# Altium schematic sheet InputChoke.SchDoc(InputChoke)
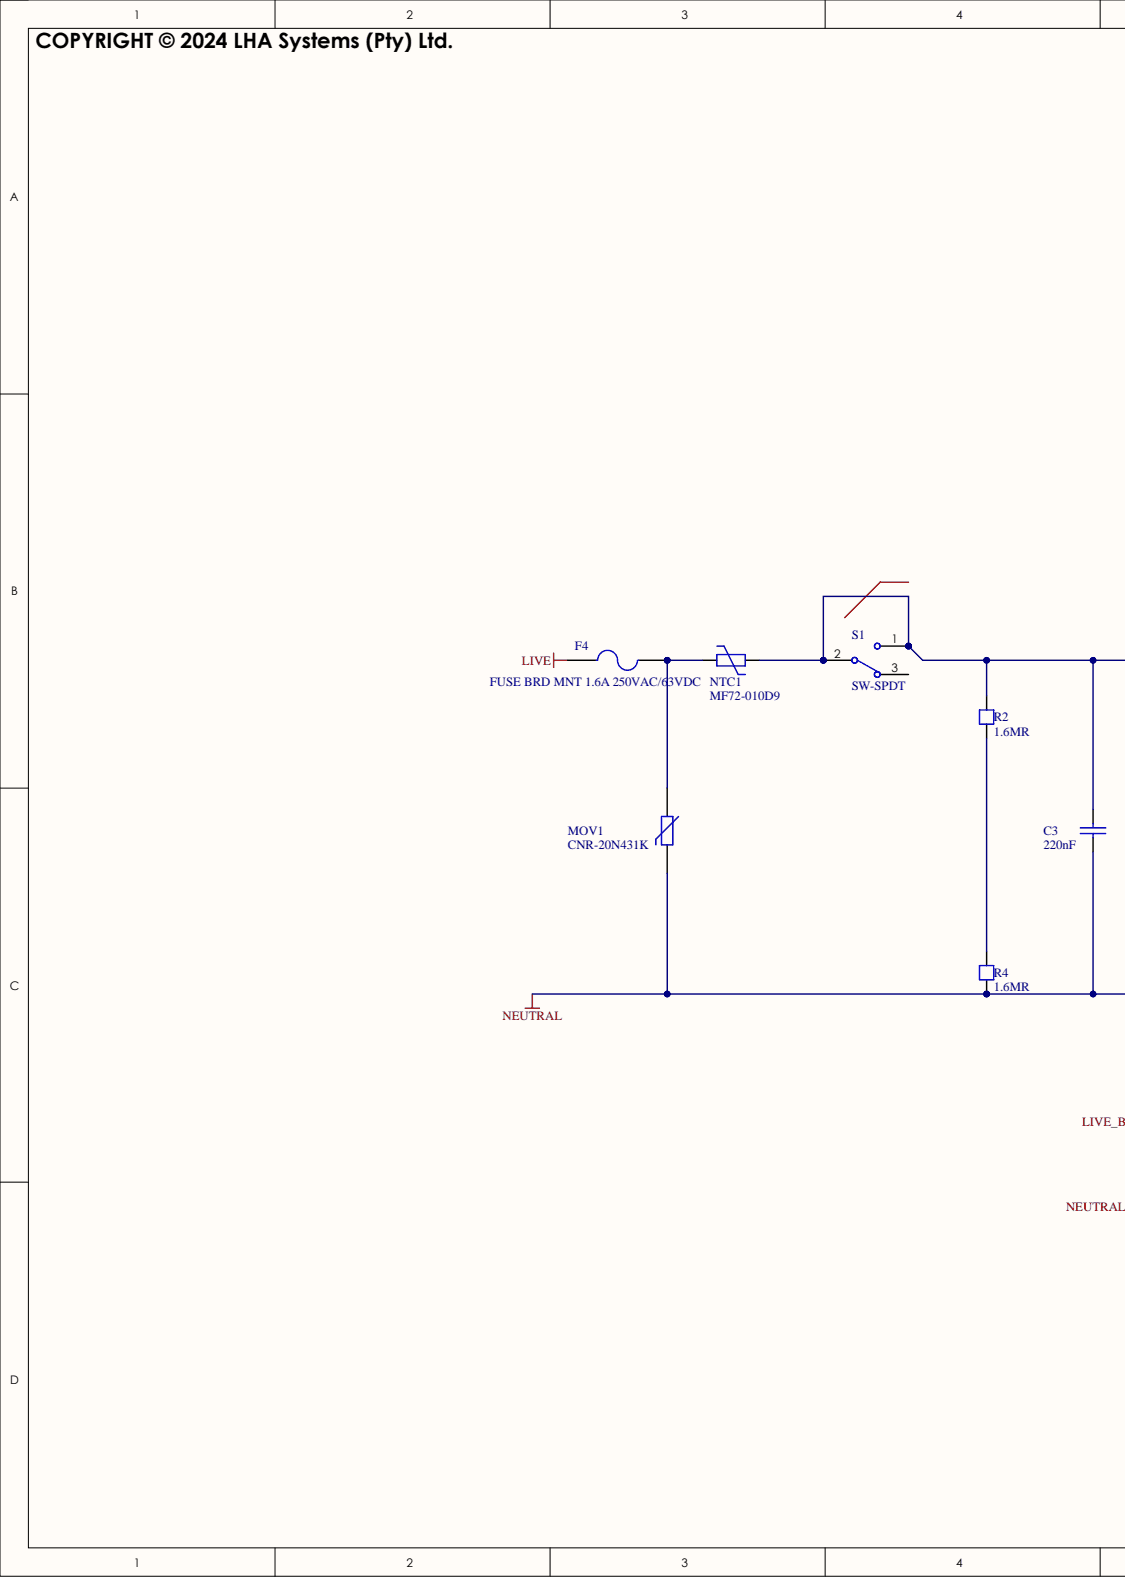
[diagram p0: InputChoke.SchDoc, left]
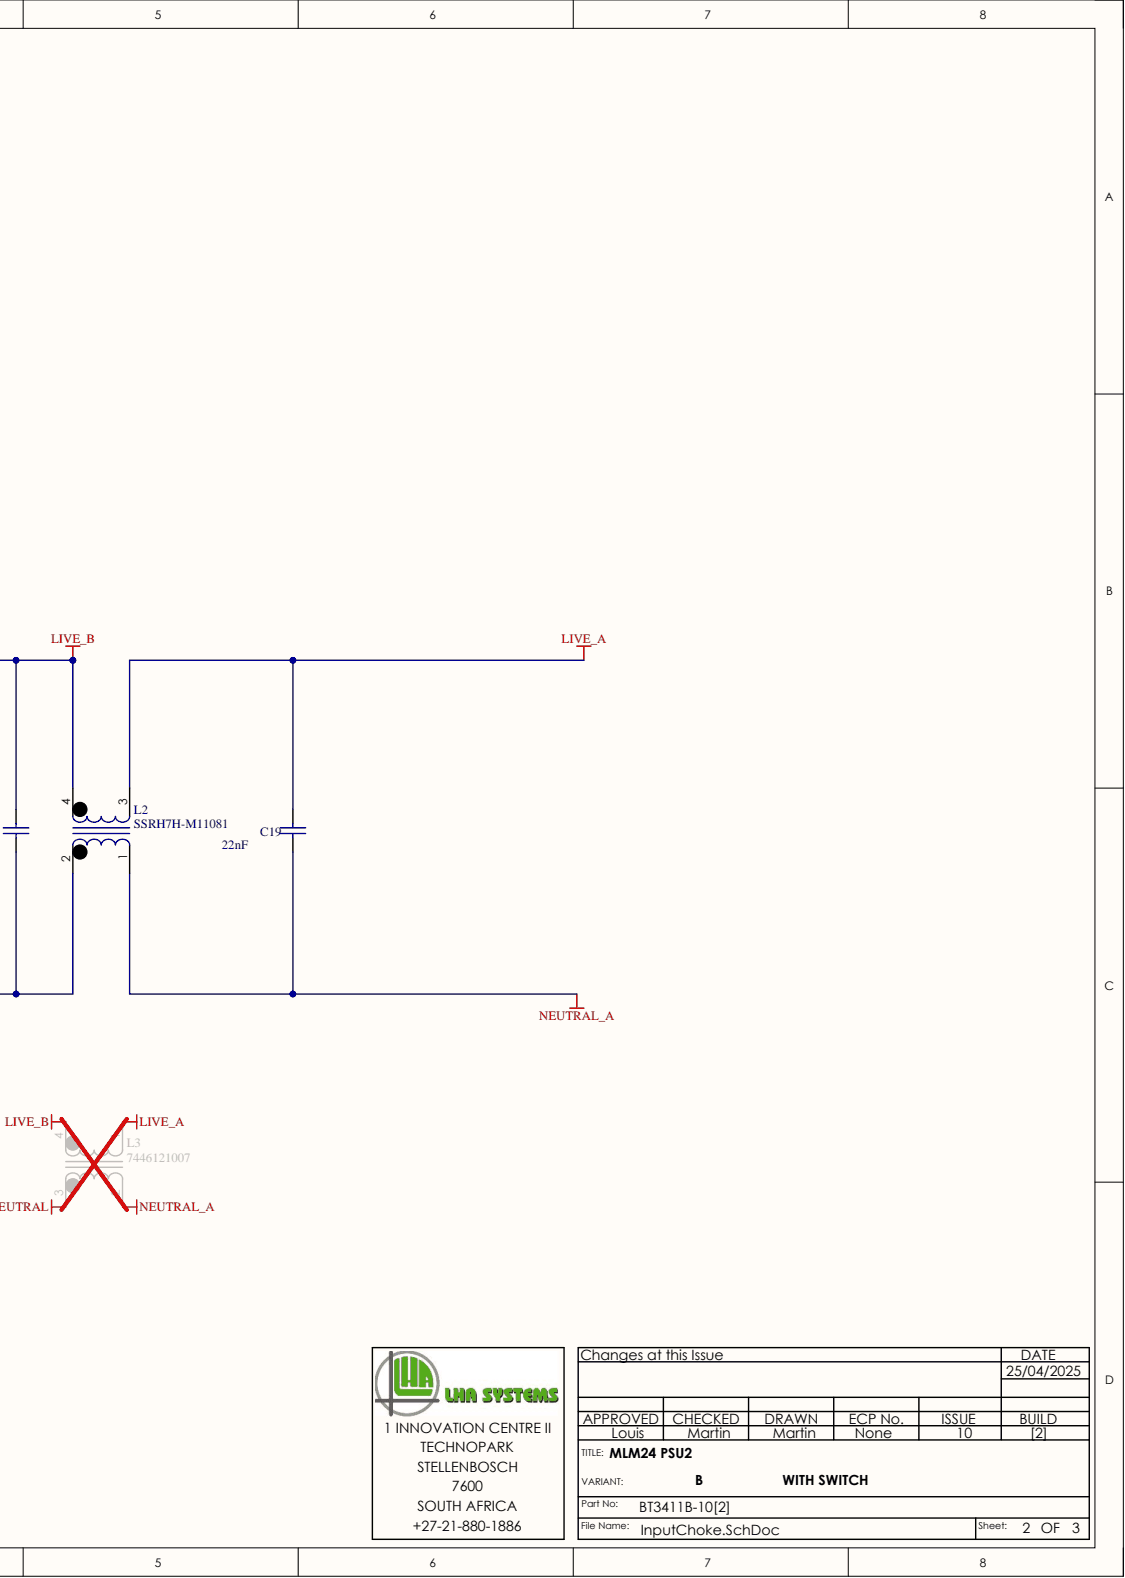
[diagram p1: InputChoke.SchDoc, right]

## Parts
C3  220nF  [Y2/X2 CAP 15MM]
C19  22nF  [RADIAL H11.5xL15xW5xPitch12.5]
F4  FUSE BRD MNT 1.6A 250VAC/63VDC  Current Rating: 1.6A  [RSTA 1.6 AMMO]
L2  SSRH7H-M11081  [SSRH7H-M]
L3  7446121007  [7446121007]
MOV1  CNR-20N431K  [CNR-20D431K]
NTC1  MF72-010D9  [LEADED DISK 7.5mm pitch]
R2  1.6MR  [1206 - OCTO]
R4  1.6MR  [1206 - OCTO]
S1  SW-SPDT  [JS-606B-R-B/B-1]

## Nets
LIVE: F4.1
LIVE_A: C19.1 L2.3 L3.1
LIVE_B: C3.1 L2.4 L3.4 NTC1.2 R2.2 S1.1 S1.2
NEUTRAL: C3.2 L2.2 L3.3 MOV1.2 R4.1
NEUTRAL_A: C19.2 L2.1 L3.2
NetF4_2: F4.2 MOV1.1 NTC1.1
NetR2_1: R2.1 R4.2

Not connected: S1 3

## Library data of the parts
C3 = CAP: Cap Polyester; Manufacturer: Panasonic Electronic Components; Manufacturer Part Number: ECQ-UAAF224M; Part Description: CAP  Polypropylene, Metallized X2 220nF 275V Radial ±20%  15.00mm; Dielectric Material: Polypropylene, Metallized; LHADescription: CAP FILM 0.22UF 20% 275VAC RAD X2; Tolerance: ±20%; Voltage Rated: 275V; Applications: X2; Capacitance: 220nF; Category: Capacitors; Component Kind: Standard; ComponentLink1Description: http://industrial.panasonic.com/www-cgi/jvcr13pz.cgi?E+PZ+4+ABD0050+ECQUAAF224M+8+WW; ComponentLink1URL: http://industrial.panasonic.com/www-cgi/jvcr13pz.cgi?E+PZ+4+ABD0050+ECQUAAF224M+8+WW; ESR (Equivalent Series Resistance): -; Features: EMI Suppression; Height - Seated (Max): 0.571" (14.50mm); Lead Pitch: 15.00mm; Mounting Type: Through Hole; Operating Temperature: -40°C ~ 110°C; PackageCase: Radial; Packaging: Bulk; Part Status: Active; Pricing: 1=0.58, 10=0.413, 25=0.3524, 50=0.3122, 100=0.2719, 250=0.2316, 500=0.2014, 1000=0.17119, 2500=0.16112 (USD); Pricing 1: 1=0.54, 10=0.38, 100=0.25, 500=0.1852, 1000=0.15742, 2500=0.14816, 5000=0.1389 (USD); RoHS: RoHS Compliant; Size / Dimension: 0.689" L x 0.295" W (17.50mm x 7.50mm); Stock: 25690; Stock 1: 240535; Supplier 1: Digi-Key; Supplier Part Number 1: P14781-ND; Termination: PC Pins; Type: CAP; Voltage Rating - AC: 275V; Voltage Rating - DC: -
C19 = CAP: Cap Polyester; Manufacturer: Panasonic Electronic Components; Manufacturer Part Number: ECQ-U2A223ML; Part Description: CAP  Polyester, Metallized X2 22nF 275V Radial ±20%  12.50mm; Dielectric Material: Polyester, Metallized; LHADescription: CAP FILM 0.022UF 20% 275VAC RAD X2; Tolerance: ±20%; Voltage Rated: 275V; Applications: X2; Capacitance: 22nF; Category: Capacitors; Component Kind: Standard; ComponentLink1Description: http://industrial.panasonic.com/www-cgi/jvcr13pz.cgi?E+PZ+3+ABD0029+ECQU2A223ML+7+WW; ComponentLink1URL: http://industrial.panasonic.com/www-cgi/jvcr13pz.cgi?E+PZ+3+ABD0029+ECQU2A223ML+7+WW; ESR (Equivalent Series Resistance): -; Features: EMI Suppression; Height - Seated (Max): 0.472" (12.00mm); Lead Pitch: 12.50mm; Mounting Type: Through Hole; Operating Temperature: -40°C ~ 100°C; PackageCase: Radial; Packaging: Bulk; Part Status: Active; Pricing: 1=0.46, 10=0.327, 25=0.2792, 50=0.2474, 100=0.2155, 250=0.18356, 500=0.1596, 1000=0.13566, 2500=0.12768 (USD); Pricing 1: 1=0.47, 10=0.323, 100=0.1938, 500=0.14536, 1000=0.12274, 2500=0.11628, 5000=0.10982 (USD); RoHS: RoHS Compliant; Size / Dimension: 0.591" L x 0.197" W (15.00mm x 5.00mm); Stock: 6350; Stock 1: 3323; Supplier 1: Digi-Key; Supplier Part Number 1: P10726-ND; Termination: PC Pins; Type: CAP; Voltage Rating - AC: 275V; Voltage Rating - DC: -
F4 = Fuse 2: Fuse; Manufacturer: Bel TRP; Manufacturer Part Number: RSTA1.6AMMO; Approvals: VDE,cURus,CQC,PSE; DC Cold Resistance: 44mR; Fuse Type: Board Mount (Cartridge Style Excluded); Voltage Rating: 72V; Voltage Rating (AC): 250V; Voltage Rating (DC): 63V; Case/Package: Radial; Category: Circuit Protection; Component Kind: Standard; ComponentLink1Description: http://belfuse.com/pdfs/RSTA.pdf?src-supplier=Avnet+Asia; ComponentLink1URL: http://belfuse.com/pdfs/RSTA.pdf?src-supplier=Avnet+Asia; ComponentLink2Description: https://belfuse.com/resources/StewartConnector/RSTA.pdf?src-supplier=Digi-Key; ComponentLink2URL: https://belfuse.com/resources/StewartConnector/RSTA.pdf?src-supplier=Digi-Key; ComponentLink3Description: http://belfuse.com/pdfs/RSTA.pdf?src-supplier=Avnet; ComponentLink3URL: http://belfuse.com/pdfs/RSTA.pdf?src-supplier=Avnet; ComponentLink4Description: http://www.mouser.com/ds/2/643/0697W-594970.pdf?src-supplier=Mouser; ComponentLink4URL: http://www.mouser.com/ds/2/643/0697W-594970.pdf?src-supplier=Mouser; ComponentLink5Description: http://belfuse.com/pdfs/0697W.pdf?src-supplier=Avnet; ComponentLink5URL: http://belfuse.com/pdfs/0697W.pdf?src-supplier=Avnet; ComponentLink6Description: http://www.belfuse.com/resources/CircuitProtection/datasheets/0697W Mar2016.pdf?src-supplier=Digi-Key; ComponentLink6URL: http://www.belfuse.com/resources/CircuitProtection/datasheets/0697W Mar2016.pdf?src-supplier=Digi-Key; Contact Plating: Tin,Matte; Height: 0.307inch; Length: 0.329inch; LHADescription: FUSE BRD MNT 1.6A 250VAC/63VDC; Max Operating Temperature: 125°C; Min Operating Temperature: -55°C; Mount: Through Hole; Number of Pins: 2; PackageCase: Radial; Packaging: Cut Tape; Part Description: FUSE BRD MNT 1.6A 250VAC/63VDC; Pricing 1: 1000=0.15761, 2000=0.14102, 3000=0.13272, 5000=0.12443, 10000=0.11613 (USD); Pricing 2: 1=0.29000 (USD); RoHS: Yes; RoHS Compliant: Yes; Simulation: FUSE; Stock 1: 1000; Stock 2: 875; Supplier 1: Digi-Key; Supplier 2: Digi-Key; Supplier Part Number 1: 507-1867-3-ND; Supplier Part Number 2: 507-1835-1-ND; Width: 0.157inch; Library Name: LHA_LIB.SchLib
L2 = SSRH7H-M11081: Manufacturer: KEMET; DC Resistance (DCR): 270mR; LHADescription: Common Mode Choke; Max Current Rating: 1.1A; Number of Lines: 2; Voltage Rating (AC): 250V; Category: Inductors, Coils, Chokes; Component Kind: Standard; ComponentLink1Description: https://api.kemet.com/component-edge/download/specsheet/SSRH7H-M11081.pdf?src-supplier=Digi-Key; ComponentLink1URL: https://api.kemet.com/component-edge/download/specsheet/SSRH7H-M11081.pdf?src-supplier=Digi-Key; Library Name: LHA_LIB_Generic.SchLib; Manufacturer Part Number: SSRH7H-M11081; Max Operating Temperature: 120°C; Min Operating Temperature: -25°C; Mount: Through Hole; PackageCase: SSRH7H-M11081; Part Description: Common Mode Choke; Pricing 1: 1=1.56000, 10=1.25000, 25=1.01560, 50=0.98980, 100=0.96370, 250=0.93764, 500=0.92980, 1000=0.82302, 5000=0.75531 (USD); RoHS: No; Stock 1: 1200; Supplier 1: Digi-Key; Supplier Part Number 1: 399-17048-ND
L3 = 7446121007: WE-LF Series Wire-wound SMD Inductor 6.8 mH ±30% Sector Winding 1A Idc; Manufacturer: Wurth Electronics; DC Resistance (DCR): 300mR; Inductance: 6.8mH; Number of Lines: 2; Test Frequency: 50Hz; Category: Common Mode Chokes; Component Kind: Standard; ComponentLink1Description: https://datasheet.ciiva.com/29370/7446121007-29370224.pdf?src-supplier=Digi-Key; ComponentLink1URL: https://datasheet.ciiva.com/29370/7446121007-29370224.pdf?src-supplier=Digi-Key; ComponentLink2Description: https://datasheet.ciiva.com/7691/1736069-7691613.pdf?src-supplier=Element14; ComponentLink2URL: https://datasheet.ciiva.com/7691/1736069-7691613.pdf?src-supplier=Element14; ComponentLink3Description: https://datasheet.ciiva.com/7211/426673-7211153.pdf?src-supplier=Newark; ComponentLink3URL: https://datasheet.ciiva.com/7211/426673-7211153.pdf?src-supplier=Newark; ComponentLink4Description: https://datasheet.ciiva.com/11800/0900766b80082742-11800795.pdf?src-supplier=RSComponents; ComponentLink4URL: https://datasheet.ciiva.com/11800/0900766b80082742-11800795.pdf?src-supplier=RSComponents; Current: 1A; Current Rating: 1A; Depth: 13.5mm; Height: 20.5mm; Length: 18.5mm; LHADescription: WE-LF Series Wire-wound SMD Inductor 6.8 mH ±30% Sector Winding 1A Idc; Manufacturer Part Number: 7446121007; Max DC Current: 1A; Max Operating Temperature: 125°C; Min Operating Temperature: -40°C; Mount: Through Hole; Package Quantity: 100; PackageCase: 7446121007; Packaging: Tray; Part Description: WE-LF Series Wire-wound SMD Inductor 6.8 mH ±30% Sector Winding 1A Idc; REACH SVHC: No SVHC; RoHS: Yes; RoHS Compliant: Yes; Series: WE-LF; Stock 1: 966; Supplier 1: RSComponents; Supplier Part Number 1: 3873592P; Termination: Radial; Tolerance: 30%; Width: 0.531inch; Library Name: LHA_LIB_Generic.SchLib
MOV1 = VARISTOR: MOV; Manufacturer: Bourns Inc.; Capacitance @ Frequency: 930pF @ 1kHz; Current-Surge: 6.5kA; Energy: 190J; LHADescription: VARISTOR 430V 6.5KA DISC 20MM; Maximum AC Volts: 275VAC; Maximum DC Volts: 350VDC; Number of Circuits: 1; Varistor Voltage: 430V; Varistor Voltage (Max): 473V; Varistor Voltage (Min): 387V; Category: Circuit Protection; Component Kind: Standard; ComponentLink1Description: http://www.bourns.com/data/global/pdfs/MOV20D.pdf; ComponentLink1URL: http://www.bourns.com/data/global/pdfs/MOV20D.pdf; ComponentLink2Description: http://www.bourns.com/docs/Product-Datasheets/MOV20D.pdf; ComponentLink2URL: http://www.bourns.com/docs/Product-Datasheets/MOV20D.pdf; Manufacturer Part Number: CNR-20N431K; Mounting Type: Through Hole; Operating Temperature: -40°C ~ 85°C (TA); PackageCase: Disc 20mm; Packaging: Tape & Reel (TR); Part Description: VARISTOR 430V 6.5KA DISC 20MM; Part Status: Active; Pricing: 1=0.57, 10=0.536, 25=0.4932, 50=0.4506, 100=0.374, 250=0.34, 500=0.289, 1000=0.255, 2500=0.238 (USD); Pricing 2: 400=0.48175, 800=0.35875, 1200=0.328, 2000=0.29725, 10000=0.2665 (USD); RoHS: RoHS Compliant; Stock: 5083; Stock 2: 1200; Supplier 1: Digi-Key; Supplier 2: Digi-Key; Supplier Part Number 1: MOV-20D431K-ND; Supplier Part Number 2: MOV-20D431KTRTR-ND; Type: MISC; Varistor Voltage (Typ): 430V
NTC1 = PTC: ICL 10 OHM 20% 2A 11MM; Manufacturer: Cantherm; Approvals: UL; R @ 25°C: 10 Ohm; R @ Current: 0.458 Ohm; Category: Circuit Protection; Component Kind: Standard; ComponentLink1Description: http://www.cantherm.com/products/inrush_current_limiter/cantherm_mf72.pdf; ComponentLink1URL: http://www.cantherm.com/products/inrush_current_limiter/cantherm_mf72.pdf; ComponentLink2Description: http://www.cantherm.com/wp-content/uploads/2017/05/MF72_JUNE_2016_1.pdf; ComponentLink2URL: http://www.cantherm.com/wp-content/uploads/2017/05/MF72_JUNE_2016_1.pdf; Current - Steady State Max: 2A; Diameter: 0.433" (11mm); Lead Pitch: 0.295" (7.50mm); LHADescription: ICL 10 OHM 20% 2A 11MM; Manufacturer Part Number: MF72-010D9; PackageCase: Radial; Packaging: Bulk; Part Description: ICL 10 OHM 20% 2A 11MM; Part Status: Active; Pricing 1: 1=0.4, 5=0.386, 10=0.375, 50=0.3154, 100=0.2618, 250=0.238, 500=0.2023, 1000=0.1785, 5000=0.16065 (USD); RoHS: RoHS Compliant; Stock 1: 7556; Supplier 1: Digi-Key; Supplier Part Number 1: 317-1161-ND; Tolerance: ±20%; Type: MISC; Library Name: LHA_LIB.SchLib
R2 R4 = RES5: See LHADescription; Manufacturer: Yageo; Manufacturer Part Number: RC1206FR-071M6L; Part Description: RES 1.6MR 1% 1206 250mW; LHADescription: RES SMD 1.6M OHM 1% 1/4W 1206; Power Rating: 250mW; Temperature Coefficient: 100ppm/°C; Tolerance: 1%; Voltage - Rated: 200V; Case Code (Imperial): 1206; Case Code (Metric): 3216; Category: Resistors; Component Kind: Standard; ComponentLink1Description: http://www.yageo.com/NewPortal/yageodocoutput?fileName=/pdf/R-Chip/PYu-RC_Group_51_RoHS_L_4.pdf; ComponentLink1URL: http://www.yageo.com/NewPortal/yageodocoutput?fileName=/pdf/R-Chip/PYu-RC_Group_51_RoHS_L_4.pdf; ComponentLink2Description: http://www.yageo.com/documents/recent/PYu-RC_Group_51_RoHS_L_7.pdf; ComponentLink2URL: http://www.yageo.com/documents/recent/PYu-RC_Group_51_RoHS_L_7.pdf; ComponentLink3Description: https://datasheet.ciiva.com/48527/wslhigh-48527589.pdf?src-supplier=Avnet; ComponentLink3URL: https://datasheet.ciiva.com/48527/wslhigh-48527589.pdf?src-supplier=Avnet; ComponentLink4Description: https://datasheet.ciiva.com/12915/e-15-16-12915349.pdf?src-supplier=Mouser; ComponentLink4URL: https://datasheet.ciiva.com/12915/e-15-16-12915349.pdf?src-supplier=Mouser; ComponentLink5Description: https://datasheet.ciiva.com/2502/rl-data-sheet-2502057.pdf?src-supplier=Digi-Key; ComponentLink5URL: https://datasheet.ciiva.com/2502/rl-data-sheet-2502057.pdf?src-supplier=Digi-Key; ComponentLink6Description: https://datasheet.ciiva.com/2502/e-15-16-2502058.pdf?src-supplier=Digi-Key; ComponentLink6URL: https://datasheet.ciiva.com/2502/e-15-16-2502058.pdf?src-supplier=Digi-Key; ComponentLink7Description: https://datasheet.ciiva.com/2502/e-15-16-2502059.pdf?src-supplier=Digi-Key; ComponentLink7URL: https://datasheet.ciiva.com/2502/e-15-16-2502059.pdf?src-supplier=Digi-Key; ComponentLink8Description: http://www.mouser.com/ds/2/447/PYu-RC1206_51_RoHS_L-4-349080.pdf?src-supplier=Mouser; ComponentLink8URL: http://www.mouser.com/ds/2/447/PYu-RC1206_51_RoHS_L-4-349080.pdf?src-supplier=Mouser; ComponentLink9Description: http://components.arrow.com/part/dataview/0323_03538893?src-supplier=Arrow+Europe; ComponentLink9URL: http://components.arrow.com/part/dataview/0323_03538893?src-supplier=Arrow+Europe; ComponentLink10Description: http://www.yageo.com.tw/exep/pages/download/literatures/PYu-R_INT-thick_7.pdf?src-supplier=Digi-Key; ComponentLink10URL: http://www.yageo.com.tw/exep/pages/download/literatures/PYu-R_INT-thick_7.pdf?src-supplier=Digi-Key; ComponentLink11Description: http://www.yageo.com/documents/recent/PYu-RC_Group_51_RoHS_L_9.pdf?src-supplier=Digi-Key; ComponentLink11URL: http://www.yageo.com/documents/recent/PYu-RC_Group_51_RoHS_L_9.pdf?src-supplier=Digi-Key; ComponentLink12Description: http://www.yageo.com/documents/recent/PYu-RC1206_51_RoHS_L_5.pdf?src-supplier=Avnet+Europe; ComponentLink12URL: http://www.yageo.com/documents/recent/PYu-RC1206_51_RoHS_L_5.pdf?src-supplier=Avnet+Europe; ComponentLink13Description: http://www.yageo.com/documents/recent/PYu-RC_Group_51_RoHS_L_10.pdf?src-supplier=Digi-Key; ComponentLink13URL: http://www.yageo.com/documents/recent/PYu-RC_Group_51_RoHS_L_10.pdf?src-supplier=Digi-Key; ComponentLink14Description: http://www.yageo.com/documents/recent/PYu-RC_Group_51_RoHS_L_2.pdf?src-supplier=Avnet; ComponentLink14URL: http://www.yageo.com/documents/recent/PYu-RC_Group_51_RoHS_L_2.pdf?src-supplier=Avnet; Composition: Thick Film; Contact Plating: Tin,Matte; Depth: 1.6mm; Height: 0.55mm; Lead Free: Lead Free; Length: 3.1mm; Max Operating Temperature: 155°C; Military Standard: Not; Min Operating Temperature: -55°C; PackageCase: 1206; Packaging: Tape and Reel; Pricing 1: 5000=0.00851, 10000=0.00739, 25000=0.00650, 50000=0.00596, 125000=0.00584 (USD); Radiation Hardening: No; Resistance: 1.6MR; RoHS: Yes; Stock 1: 50000; Supplier 1: Digi-Key; Supplier Part Number 1: 311-1.60MFRTR-ND; Termination: SMD/SMT; Voltage Rating (DC): 200V; Width: 1.6mm
S1 = SW-SPDT: SPDT Subminiature Toggle Switch, Right Angle Mounting, Vertical Actuation; LHADescription: Switch SPDT; Category: Switch; Component Kind: Standard; ComponentLink1Description: Manufacturer Link; ComponentLink1URL: http://www.apem.com; DatasheetVersion: 05-Dec-2000; LatestRevisionDate: 17-Dec-2003; LatestRevisionNote: Schematic revised, PCB Footprint replaced.; Library Name: LHA_LIB.SchLib; PackageCase: 110VAC-220VAC OCTAL; Part Description: Switch SPDT; Published: 24-Mar-1999; Publisher: Altium Limited
